# Altium schematic sheet [03]Breakout Headers.SchDoc
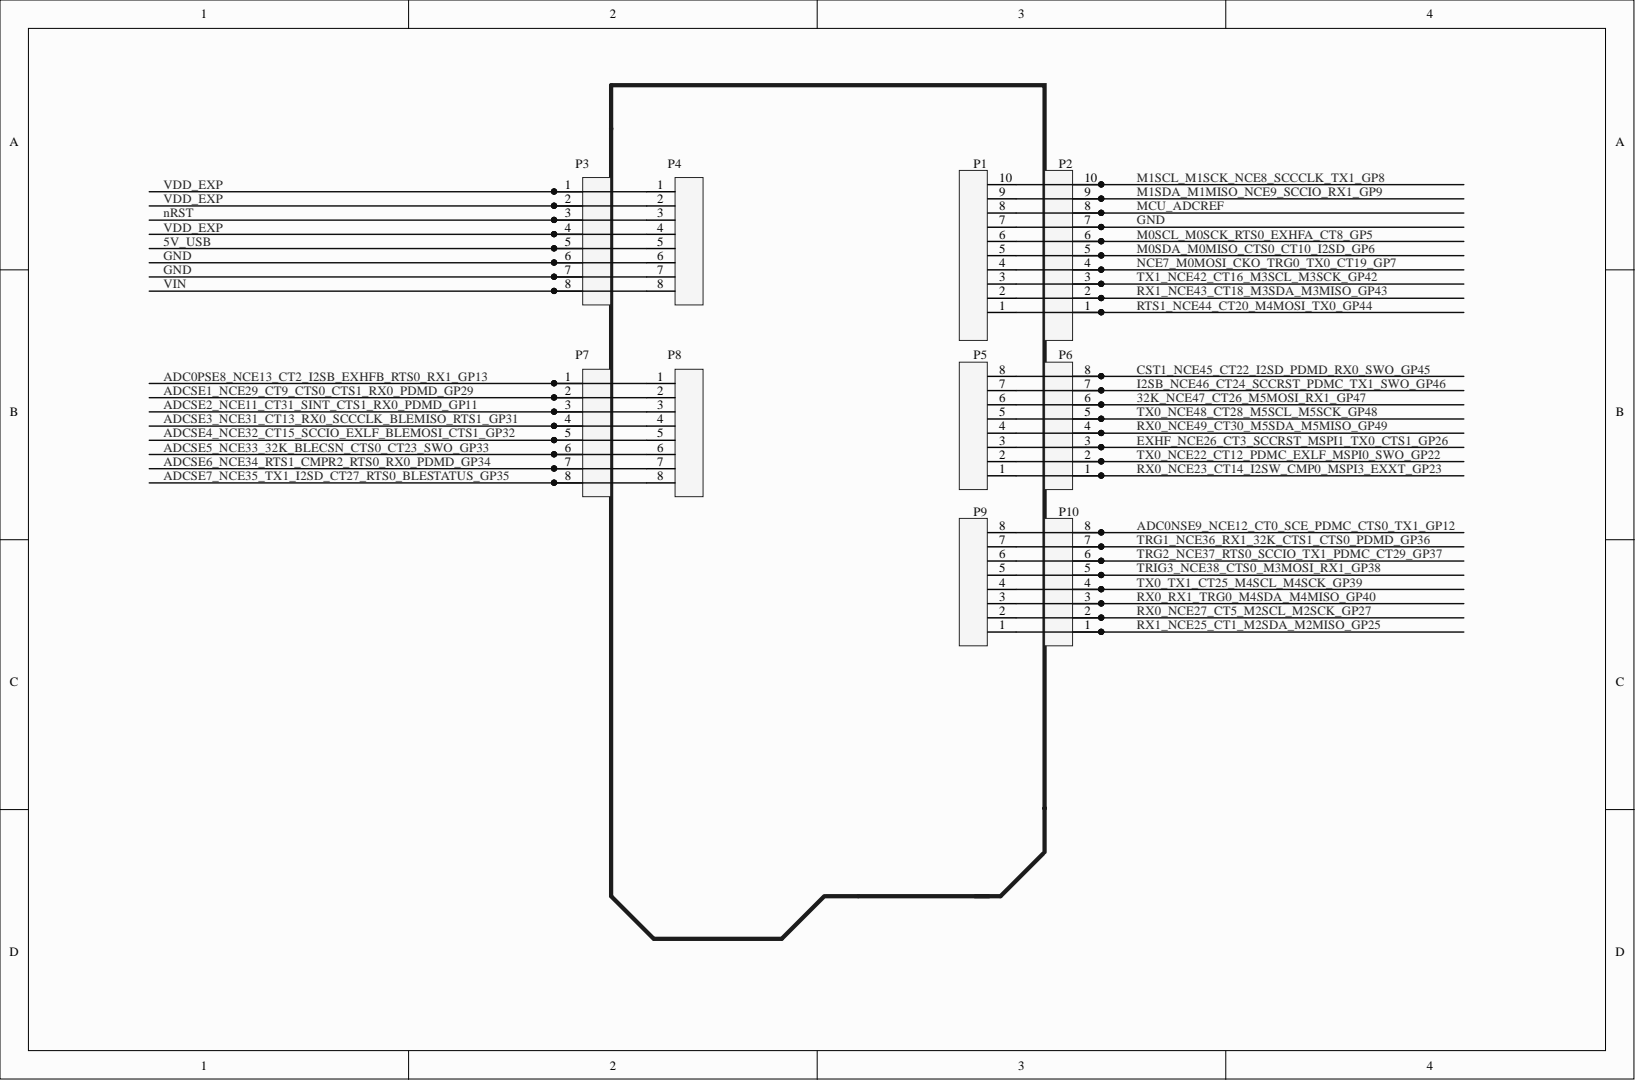
[diagram p0: [03]Breakout Headers.SchDoc]

## Parts
P1  Header 1X10  [HEADER1X10]  (value not drawn)  (no BOM)
P2  Header 1X10  [HEADER1X10]  (value not drawn)  (no BOM)
P3  Header 1X8  [HEADER1X8]  (value not drawn)  (no BOM)
P4  Header 1X8  [HEADER1X8]  (value not drawn)  (no BOM)
P5  Header 1X8  [HEADER1X8]  (value not drawn)  (no BOM)
P6  Header 1X8  [HEADER1X8]  (value not drawn)  (no BOM)
P7  Header 1X8  [HEADER1X8]  (value not drawn)  (no BOM)
P8  Header 1X8  [HEADER1X8]  (value not drawn)  (no BOM)
P9  Header 1X8  [HEADER1X8]  (value not drawn)  (no BOM)
P10  Header 1X8  [HEADER1X8]  (value not drawn)  (no BOM)

## Nets
5V_USB: P3.5 P4.5
32K_NCE47_CT26_M5MOSI_RX1_GP47: P5.6 P6.6
ADC0NSE9_NCE12_CT0_SCE_PDMC_CTS0_TX1_GP12: P9.8 P10.8
ADC0PSE8_NCE13_CT2_I2SB_EXHFB_RTS0_RX1_GP13: P7.1 P8.1
ADCSE1_NCE29_CT9_CTS0_CTS1_RX0_PDMD_GP29: P7.2 P8.2
ADCSE2_NCE11_CT31_SINT_CTS1_RX0_PDMD_GP11: P7.3 P8.3
ADCSE3_NCE31_CT13_RX0_SCCCLK_BLEMISO_RTS1_GP31: P7.4 P8.4
ADCSE4_NCE32_CT15_SCCIO_EXLF_BLEMOSI_CTS1_GP32: P7.5 P8.5
ADCSE5_NCE33_32K_BLECSN_CTS0_CT23_SWO_GP33: P7.6 P8.6
ADCSE6_NCE34_RTS1_CMPR2_RTS0_RX0_PDMD_GP34: P7.7 P8.7
ADCSE7_NCE35_TX1_I2SD_CT27_RTS0_BLESTATUS_GP35: P7.8 P8.8
CST1_NCE45_CT22_I2SD_PDMD_RX0_SWO_GP45: P5.8 P6.8
EXHF_NCE26_CT3_SCCRST_MSPI1_TX0_CTS1_GP26: P5.3 P6.3
GND: P1.7 P2.7 P3.6 P3.7 P4.6 P4.7
I2SB_NCE46_CT24_SCCRST_PDMC_TX1_SWO_GP46: P5.7 P6.7
M0SCL_M0SCK_RTS0_EXHFA_CT8_GP5: P1.6 P2.6
M0SDA_M0MISO_CTS0_CT10_I2SD_GP6: P1.5 P2.5
M1SCL_M1SCK_NCE8_SCCCLK_TX1_GP8: P1.10 P2.10
M1SDA_M1MISO_NCE9_SCCIO_RX1_GP9: P1.9 P2.9
MCU_ADCREF: P1.8 P2.8
NCE7_M0MOSI_CKO_TRG0_TX0_CT19_GP7: P1.4 P2.4
nRST: P3.3 P4.3
RTS1_NCE44_CT20_M4MOSI_TX0_GP44: P1.1 P2.1
RX0_NCE23_CT14_I2SW_CMP0_MSPI3_EXXT_GP23: P5.1 P6.1
RX0_NCE27_CT5_M2SCL_M2SCK_GP27: P9.2 P10.2
RX0_NCE49_CT30_M5SDA_M5MISO_GP49: P5.4 P6.4
RX0_RX1_TRG0_M4SDA_M4MISO_GP40: P9.3 P10.3
RX1_NCE25_CT1_M2SDA_M2MISO_GP25: P9.1 P10.1
RX1_NCE43_CT18_M3SDA_M3MISO_GP43: P1.2 P2.2
TRG1_NCE36_RX1_32K_CTS1_CTS0_PDMD_GP36: P9.7 P10.7
TRG2_NCE37_RTS0_SCCIO_TX1_PDMC_CT29_GP37: P9.6 P10.6
TRIG3_NCE38_CTS0_M3MOSI_RX1_GP38: P9.5 P10.5
TX0_NCE22_CT12_PDMC_EXLF_MSPI0_SWO_GP22: P5.2 P6.2
TX0_NCE48_CT28_M5SCL_M5SCK_GP48: P5.5 P6.5
TX0_TX1_CT25_M4SCL_M4SCK_GP39: P9.4 P10.4
TX1_NCE42_CT16_M3SCL_M3SCK_GP42: P1.3 P2.3
VDD_EXP: P3.1 P3.2 P3.4 P4.1 P4.2 P4.4
VIN: P3.8 P4.8

## Library data of the parts
P1 P2 = HDR1X10: Description: Header 1X10; Library Name: Connector.SchLib
P3-P10 = HDR1X8: Library Name: Connector.SchLib
